# Altium schematic sheet 08 - USB.SchDoc
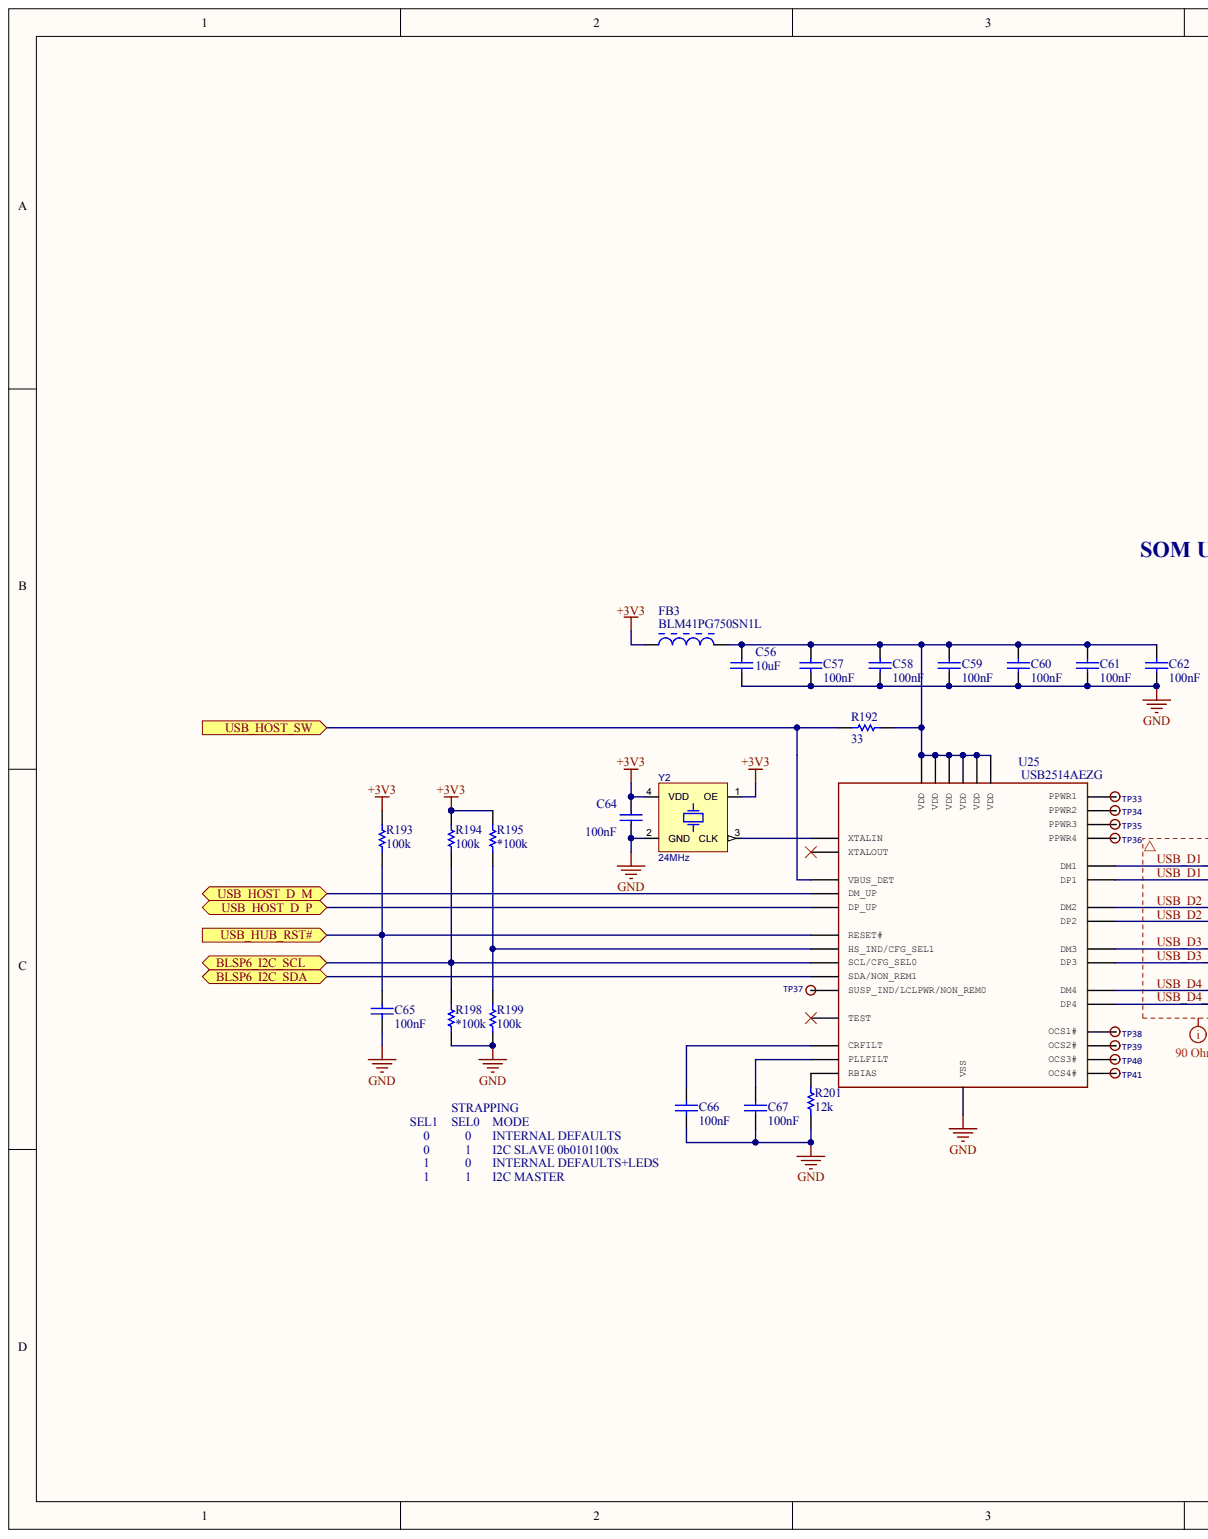
[diagram p0: 08 - USB.SchDoc, left]
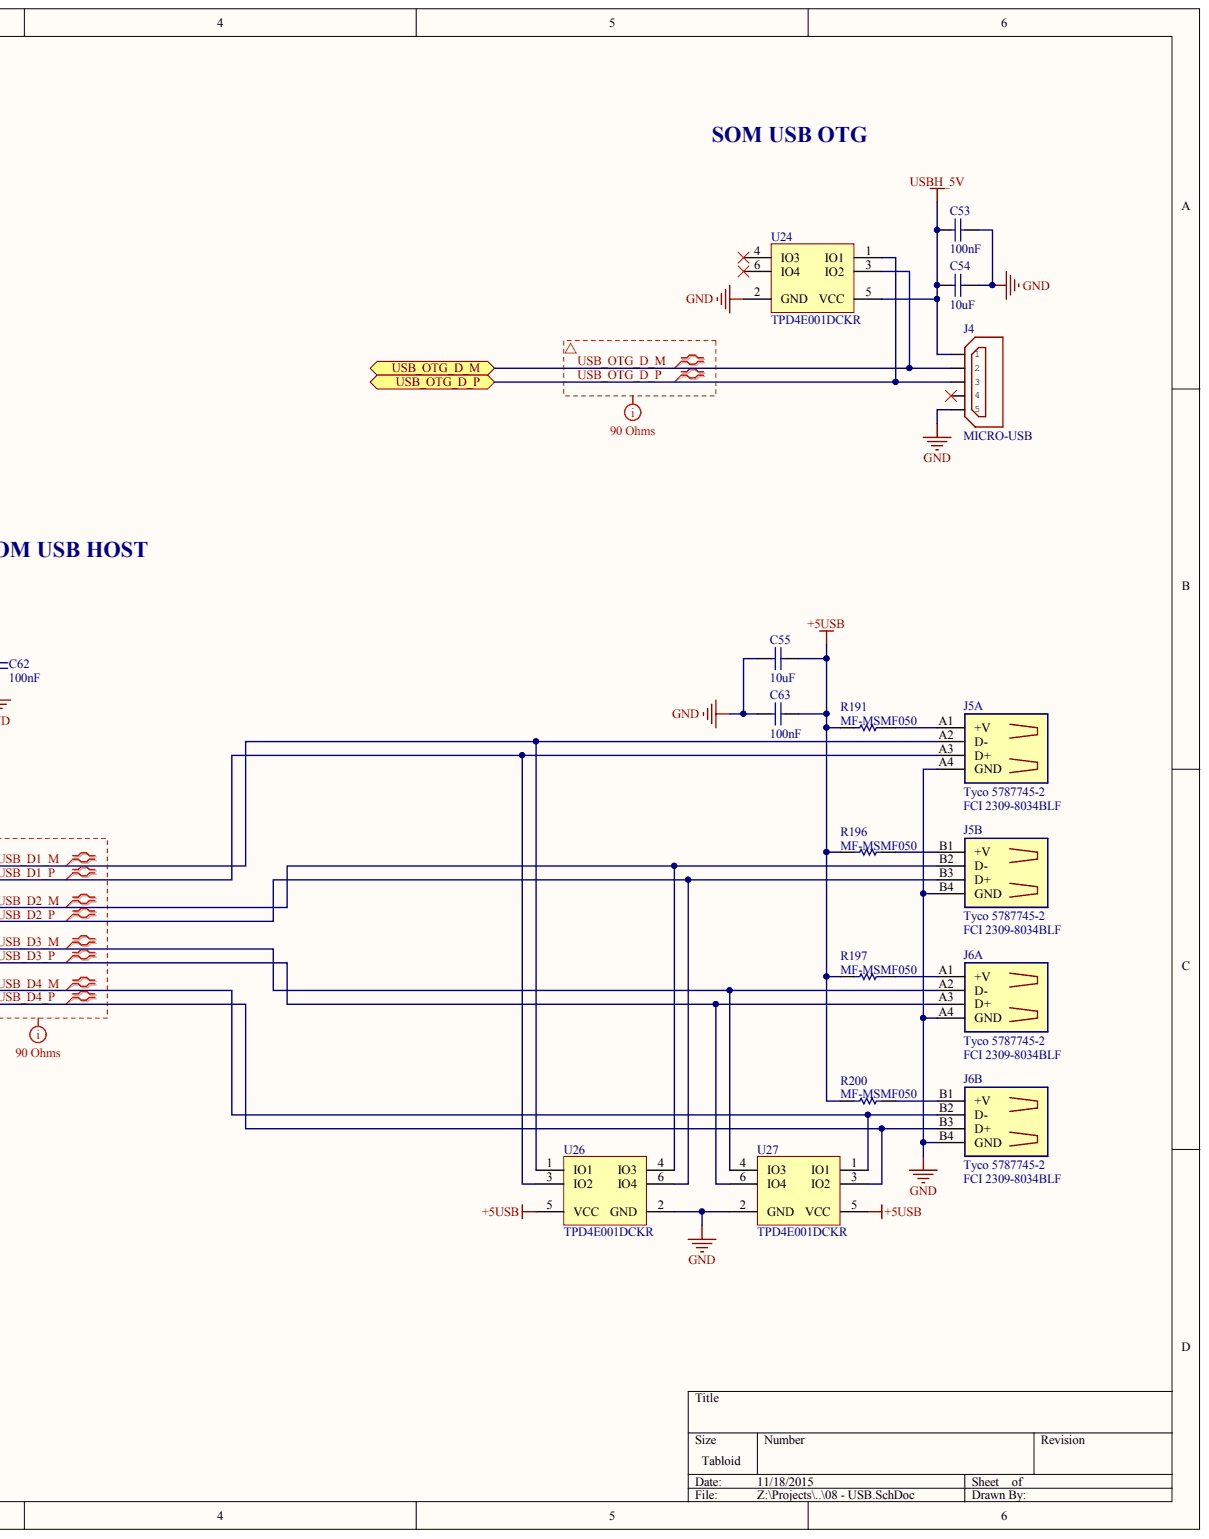
[diagram p1: 08 - USB.SchDoc, right]

## Parts
C53  value 100nF  [CAPC0805(2012)100_N]  (hidden comment: CAP 100nF 6.3V 0805(2012))
C54  value 10uF  [CAPC0805(2012)100_L]  (hidden comment: CAP 10uF 6.3V 0805(2012))
C55  value 10uF  [CAPC0805(2012)100_L]  (hidden comment: CAP 10uF 6.3V 0805(2012))
C56  value 10uF  [CAPC0805(2012)100_N]  (hidden comment: CAP 10uF 6.3V 0805(2012))
C57  value 100nF  [CAPC0805(2012)100_N]  (hidden comment: CAP 100nF 6.3V 0805(2012))
C58  value 100nF  [CAPC0805(2012)100_N]  (hidden comment: CAP 100nF 6.3V 0805(2012))
C59  value 100nF  [CAPC0805(2012)100_N]  (hidden comment: CAP 100nF 6.3V 0805(2012))
C60  value 100nF  [CAPC0805(2012)100_N]  (hidden comment: CAP 100nF 6.3V 0805(2012))
C61  value 100nF  [CAPC0805(2012)100_N]  (hidden comment: CAP 100nF 6.3V 0805(2012))
C62  value 100nF  [CAPC0805(2012)100_N]  (hidden comment: CAP 100nF 6.3V 0805(2012))
C63  value 100nF  [CAPC0805(2012)100_N]  (hidden comment: CAP 100nF 6.3V 0805(2012))
C64  value 100nF  [CAPC0805(2012)100_N]  (hidden comment: CAP 100nF 6.3V 0805(2012))
C65  value 100nF  [CAPC0805(2012)100_N]  (hidden comment: CAP 100nF 6.3V 0805(2012))
C66  value 100nF  [CAPC0805(2012)100_N]  (hidden comment: CAP 100nF 6.3V 0805(2012))
C67  value 100nF  [CAPC0805(2012)100_N]  (hidden comment: CAP 100nF 6.3V 0805(2012))
FB3  BLM41PG750SN1L  [MURA-BLM41-B35-CHIP-2_V2]
J4  MICRO-USB  [USB-MICRO]
J5  Tyco 5787745-2 / FCI 2309-8034BLF  [STACKEDUSB_TH]  (parts A,B)
J6  Tyco 5787745-2 / FCI 2309-8034BLF  [STACKEDUSB_TH]  (parts A,B)
R191  MF-MSMF050  [BOUR-MF-MSMF050-2_V]
R192  value 33  [RESC0805(2012)_L]  (hidden comment: 33R 1% 0805(2012))
R193  100k  [RESC0805(2012)_M]
R194  100k  [RESC0805(2012)_M]
R195  100k  value *100k  [RESC0805(2012)_M]
R196  MF-MSMF050  [BOUR-MF-MSMF050-2_V]
R197  MF-MSMF050  [BOUR-MF-MSMF050-2_V]
R198  100k  value *100k  [RESC0805(2012)_M]
R199  100k  [RESC0805(2012)_M]
R200  MF-MSMF050  [BOUR-MF-MSMF050-2_V]
R201  12k  [RESC0805(2012)_M]
TP33  (no value)  [TESTPOINT_035HOLE]
TP34  (no value)  [TESTPOINT_035HOLE]
TP35  (no value)  [TESTPOINT_035HOLE]
TP36  (no value)  [TESTPOINT_035HOLE]
TP37  (no value)  [TESTPOINT_035HOLE]
TP38  (no value)  [TESTPOINT_035HOLE]
TP39  (no value)  [TESTPOINT_035HOLE]
TP40  (no value)  [TESTPOINT_035HOLE]
TP41  (no value)  [TESTPOINT_035HOLE]
U24  TPD4E001DCKR  [DCK0006A_M]
U25  USB2514AEZG  [QFN36]
U26  TPD4E001DCKR  [DCK0006A_M]
U27  TPD4E001DCKR  [DCK0006A_M]
Y2  24MHz  [OSC_DFN165P250X90-4N]

## Ports
BLSP6_I2C_SCL, BLSP6_I2C_SDA, USB_HOST_D_M, USB_HOST_D_P, USB_HOST_SW, USB_HUB_RST#, USB_OTG_D_M, USB_OTG_D_P

## Nets
+3V3: C64.1 FB3.1 R193.2 R194.2 R195.2 Y2.1(OE) Y2.4(VDD)
+5USB: C55.2 C63.1 R191.1 R196.1 R197.1 R200.1 U26.5(VCC) U27.5(VCC)
GND: C53.2 C54.1 C55.1 C56.1 C57.1 C58.1 C59.1 C60.1 C61.1 C62.1 C63.2 C64.2 C65.1 C66.1 C67.1 J4.ID J5.A4(GND) J5.B4(GND) J6.A4(GND) J6.B4(GND) R198.1 R199.1 R201.1 U24.2(GND) U25.PAD(VSS) U26.2(GND) U27.2(GND) Y2.2(GND)
USBH_5V: C53.1 C54.2 J4.VBUS U24.5(VCC)
BLSP6_I2C_SCL: R194.1 R198.2 U25.24(SCL/CFG_SEL0) ; port BLSP6_I2C_SCL
BLSP6_I2C_SDA: U25.22(SDA/NON_REM1) ; port BLSP6_I2C_SDA
USB_D1_M: J5.A2(D-) U25.1(DM1) U26.1(IO1)
USB_D1_P: J5.A3(D+) U25.2(DP1) U26.3(IO2)
USB_D2_M: J5.B2(D-) U25.3(DM2) U26.4(IO3)
USB_D2_P: J5.B3(D+) U25.4(DP2) U26.6(IO4)
USB_D3_M: J6.A2(D-) U25.6(DM3) U27.4(IO3)
USB_D3_P: J6.A3(D+) U25.7(DP3) U27.6(IO4)
USB_D4_M: J6.B2(D-) U25.8(DM4) U27.1(IO1)
USB_D4_P: J6.B3(D+) U25.9(DP4) U27.3(IO2)
USB_HOST_D_M: U25.30(DM_UP) ; port USB_HOST_D_M
USB_HOST_D_P: U25.31(DP_UP) ; port USB_HOST_D_P
USB_HOST_SW: R192.2 U25.27(VBUS_DET) ; port USB_HOST_SW
USB_HUB_RST#: C65.2 R193.1 U25.26(RESET#) ; port USB_HUB_RST#
USB_OTG_D_M: J4.D- U24.3(IO2) ; port USB_OTG_D_M
USB_OTG_D_P: J4.D+ U24.1(IO1) ; port USB_OTG_D_P
NetC56_2: C56.2 C57.2 C58.2 C59.2 C60.2 C61.2 C62.2 FB3.2 R192.1 U25.5(VDD) U25.10(VDD) U25.15(VDD) U25.23(VDD) U25.29(VDD) U25.36(VDD)
NetC66_2: C66.2 U25.14(CRFILT)
NetC67_2: C67.2 U25.34(PLLFILT)
NetJ5_A1: J5.A1(+V) R191.2
NetJ5_B1: J5.B1(+V) R196.2
NetJ6_A1: J6.A1(+V) R197.2
NetJ6_B1: J6.B1(+V) R200.2
NetR195_1: R195.1 R199.2 U25.25(HS_IND/CFG_SEL1)
NetR201_2: R201.2 U25.35(RBIAS)
NetTP33_1: TP33.1 U25.12(PPWR1)
NetTP34_1: TP34.1 U25.16(PPWR2)
NetTP35_1: TP35.1 U25.18(PPWR3)
NetTP36_1: TP36.1 U25.20(PPWR4)
NetTP37_1: TP37.1 U25.28(SUSP_IND/LCLPWR/NON_REM0)
NetTP38_1: TP38.1 U25.13(OCS1#)
NetTP39_1: TP39.1 U25.17(OCS2#)
NetTP40_1: TP40.1 U25.19(OCS3#)
NetTP41_1: TP41.1 U25.21(OCS4#)
NetU25_33: U25.33(XTALIN) Y2.3(CLK)
N00625:
N00626:

Not connected: J4 GND; U24 4(IO3) 6(IO4); U25 11(TEST) 32(XTALOUT)

## Library data of the parts
C53 C57-C67 = CMP-1036-04391-1: CAP 100nF 6.3V Â±10% 0805 (2012 Metric) Thickness 1mm SMD; Case-EIA: 0805; Case-Metric: 2012; Component Kind: Standard; Library Name: Altium Content Vault; Max Thickness: 1 mm; Rated Voltage: 6.3 V; Technology: SMT; Tolerance: Â±10%
C54 C55 = CMP-1036-04917-1: CAP 10uF 6.3V Â±10% 0805 (2012 Metric) Thickness 1mm SMD; Case-EIA: 0805; Case-Metric: 2012; Component Kind: Standard; Library Name: Altium Content Vault; Max Thickness: 1 mm; Rated Voltage: 6.3 V; Technology: SMT; Tolerance: Â±10%
C56 = CMP-1036-04920-1: CAP 10uF 6.3V Â±20% 0805 (2012 Metric) Thickness 1mm SMD; Case-EIA: 0805; Case-Metric: 2012; Component Kind: Standard; Library Name: Altium Inc., Content Vault; Max Thickness: 1 mm; Rated Voltage: 6.3 V; Technology: SMT; Tolerance: Â±20%
FB3 = CMP-0686-00749-2: Chip Ferrite Bead for Power Lines, 75 Ohm, 3500 mA, -55 to 125 degC, 4.5 x 1.6 x 1.8 mm SMD, Tape and Reel; Manufacturer: Murata; DC Resistance: 0.015 Ohm Max.; Impedance (at 100 MHz): 75 Ohm Typ.; Rated Current: 3500 mA; Component Kind: Standard; ComponentLink1Description: Manufacturer URL; ComponentLink1URL: http://www.murata.com/; ComponentLink2Description: Datasheet; ComponentLink2URL: http://www.murata.com/products/catalog/pdf/c31e.pdf; ComponentLink3Description: Package Specification; ComponentLink3URL: http://www.murata.com/products/catalog/pdf/c31e.pdf; DatasheetVersion: 08/2013; Library Name: Altium Content Vault; Mounting Technology: Surface Mount; Operating Temperature Range: -55 to 125 degC; PackageDescription: 2-Pin Chip, Body 4.5 x 1.6 mm; PackageReference: BLM41-2; Packing: Tape and Reel; PartNumber: BLM41PG750SN1L
J5 J6 = STACKED_USB_ATH: Component Kind: Standard; Library Name: ak-misc.SCHLIB
R191 R196 R197 R200 = CMP-0280-00002-2: MF-MSMF Series - PTC Resettable Fuse, 15 V Max, 0.15 Ohm Min, -40 to 85 degC, RoHS, Reel; Manufacturer: Bourns; Current Rating (Max): 100 A; Hold Current: 0.5 A; Trip Current: 1 A; Voltage DC (Max): 15 V; Component Kind: Standard; ComponentLink1Description: Manufacturer URL; ComponentLink1URL: http://www.bourns.com/; ComponentLink2Description: Datasheet; ComponentLink2URL: http://www.bourns.com/data/global/pdfs/mfmsmf.pdf; ComponentLink3Description: Package Specification; ComponentLink3URL: http://www.bourns.com/data/global/pdfs/mfmsmf.pdf; DatasheetVersion: Rev. AD; Library Name: Altium Content Vault; Mounting Technology: Surface Mount; Operating Temperature Range: -40 to 85 degC; PackageDescription: 2-Pin SMD, Body 4.55 x 3.24 mm; PackageReference: MF-MSMF050; Packing: Reel; PartNumber: MF-MSMF050; Resistance (Min): 0.15 Ohm; RoHS: TRUE
R192 = CMP-1013-00341-1: 33R 0.125W 1% 0805 (2012 Metric)  SMD; Case-EIA: 0805; Case-Metric: 2012; Component Kind: Standard; Library Name: Altium Content Vault; Power: 0.125 W; Technology: SMT; Tolerance: 1 %
R193-R195 R198 R199 R201 = CMP-1013-00623-1: 10K 0.125W 1% 0805 (2012 Metric)  SMD; Case-EIA: 0805; Case-Metric: 2012; Component Kind: Standard; Library Name: Altium Content Vault; Power: 0.125 W; Technology: SMT; Tolerance: 1 %
  R193 R194 R199: Value: 100k
  R201: Value: 12k
TP33-TP41 = TESTPOINTHOLE035: Component Kind: Standard; Library Name: ak-misc.SCHLIB
U24 U26 U27 = CMP-0326-00066-2: Low-Capacitance + / - 15-kV ESD Protection Array for High-Speed Data Interfaces, 4 Channels, -40 to +85 degC, 6-pin SOT70 (DCK), Green (RoHS & no Sb/Br); Manufacturer: Texas Instruments; 1394 / Firewire: TRUE; Breakdown Voltage(Min)(V): 11; IEC 61000-4-2 Air-Gap(+/- kV): 15; IEC 61000-4-2 Contact(+/- kV): 8; IO Capacitance(Typ)(pF): 1.5; SubFamily: ESD Solution; Component Kind: Standard; ComponentLink1Description: Manufacturer URL; ComponentLink1URL: http://www.ti.com/; ComponentLink2Description: Datasheet; ComponentLink2URL: http://www.ti.com/general/docs/lit/getliterature.tsp?genericPartNumber=TPD4E001&fileType=pdf; ComponentLink3Description: URL Link1; ComponentLink3URL: SamplesURL:http://www.ti.com/product/tpd4e001#samples; DatasheetVersion: SLLS682G; Eco-Plan: Green (RoHS & no Sb/Br); IO Leakage Current(nA): 1.5; Library Name: Altium Inc., Content Vault; Mounting Technology: Surface Mount; PackageDescription: 6-Pin Plastic Small-Outline Package, 2 x 1.3 mm Body, 0.65 mm Pitch, 2.1 mm Lead Span; PackageReference: DCK0006A; PackageVersion: revG, Jan-2007; PartNumber: TPD4E001DCKR; Serial ATA / eSATA: TRUE; USB High Speed: TRUE
Y2 = OSC_MEMS_8.000MHZ: OSC CMEMS 24MHZ LVCMOS SMD; Manufacturer: Silicon Labs; Manufacturer Part Number: 501ABA8M00000DAG; Current - Supply (Disable) (Max): 2.5mA; Frequency Stability: ±30ppm; Function: Tri-State (Output Enable); Voltage - Supply: 1.7 V ~ 3.6 V; Category: Crystals and Oscillators; Component Kind: Standard; ComponentLink1Description: http://www.silabs.com/Support%20Documents/TechnicalDocs/Si501-2-3.pdf; ComponentLink1URL: http://www.silabs.com/Support%20Documents/TechnicalDocs/Si501-2-3.pdf; Current - Supply (Max): 2.5mA; Frequency: 24MHz; Height: 0.035" (0.90mm); Library Name: RevAir32.IntLib; Mounting Type: Surface Mount; Operating Temperature: -40°C ~ 85°C; Output: LVCMOS; Package / Case: 4-SMD, No Lead (DFN, LCC); Packaging: Cut Strip; Pricing 1: 1=1.04, 10=0.92, 50=0.86, 100=0.76, 500=0.72, 1000=0.6, 2500=0.58, 5000=0.56 (USD); Ratings: -; RoHS: RoHS Compliant; Size / Dimension: 0.098" L x 0.079" W (2.50mm x 2.00mm); Stock 1: 150; Supplier 1: Digi-Key; Supplier Part Number 1: 336-2873-ND; Type: CMEMS®
J4 = MICRO-USB: Component Kind: Standard; Library Name: ak-misc.IntLib
U25 = USB2514AEZG: Component Kind: Standard; Library Name: ak-misc.IntLib
